# Altium schematic sheet LIN.SchDoc
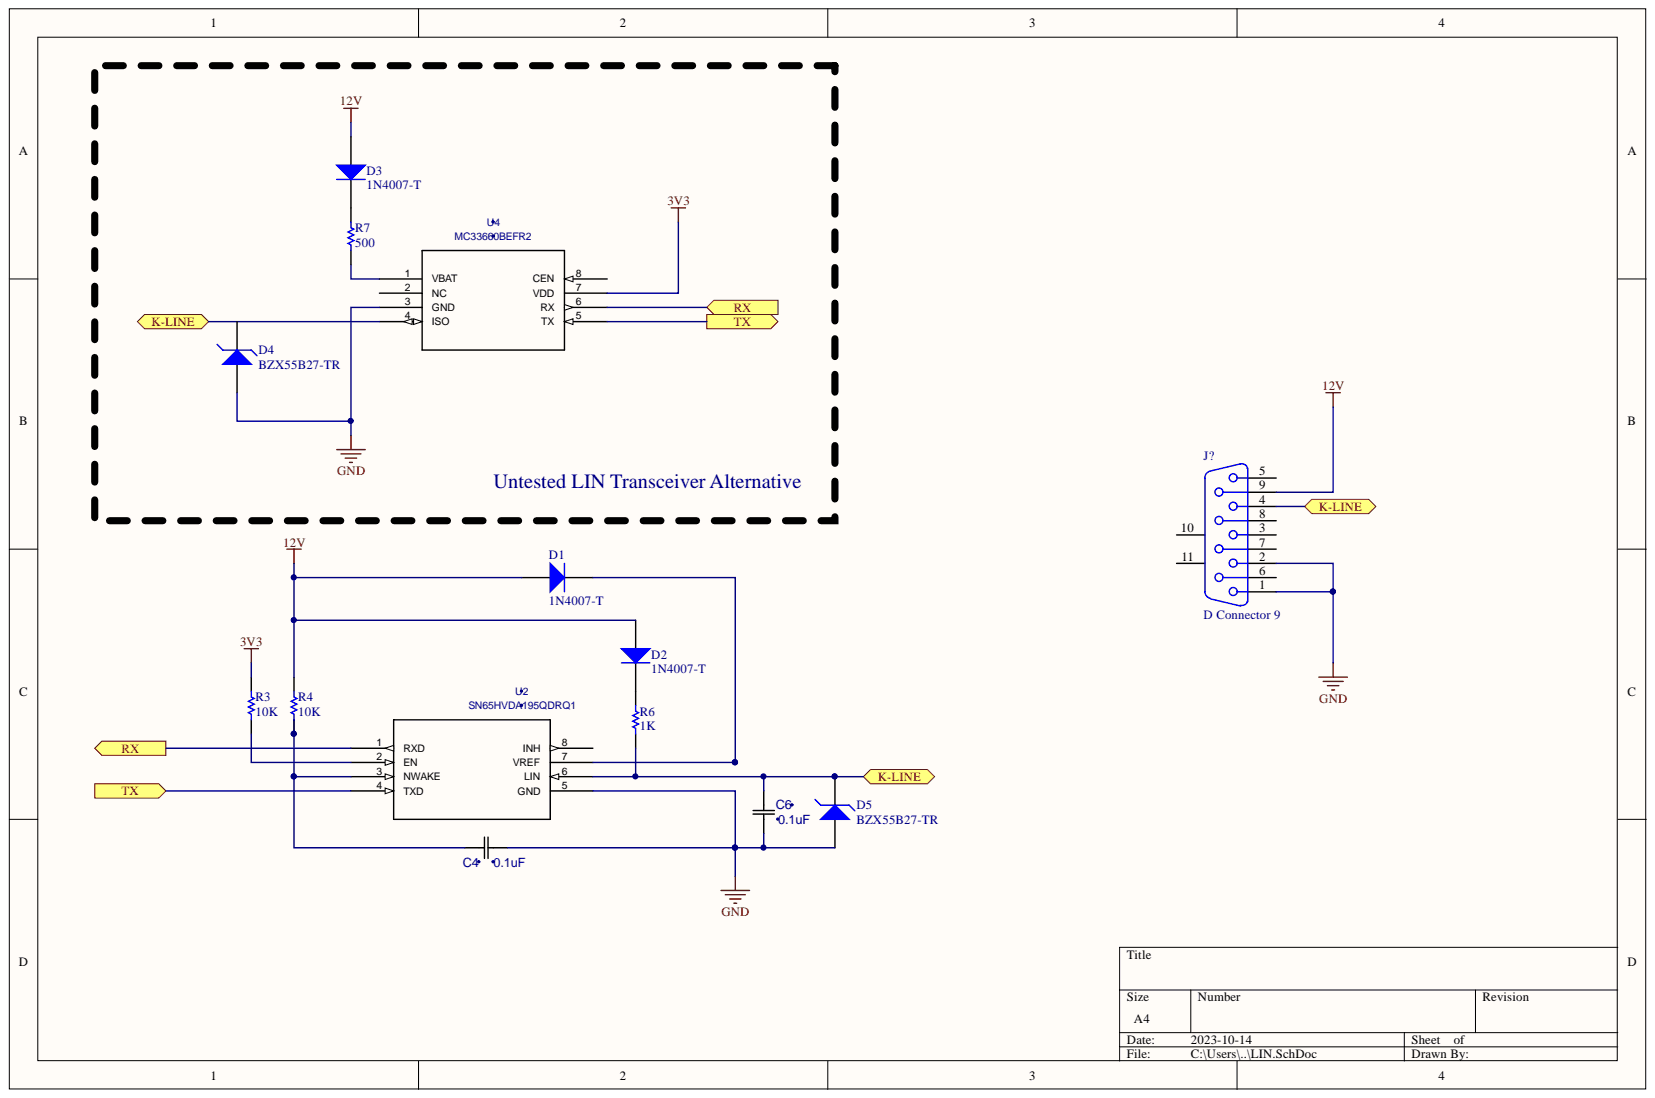
[diagram p0: LIN.SchDoc]

## Parts
C4  value 0.1uF  [CAP_TH_CER_5MM]  (hidden comment: CAP_TH_CER)
C6  value 0.1uF  [CAP_TH_CER_5MM]  (hidden comment: CAP_TH_CER)
D1  1N4007-T
D2  1N4007-T
D3  1N4007-T
D4  BZX55B27-TR
D5  BZX55B27-TR
J?  D Connector 9  [DSUB1.385-2H9]
R3  10K
R4  10K
R6  1K
R7  500
U2  SN65HVDA195QDRQ1  [8-Pin SOIC]
U4  MC33660BEFR2  [8-SOIC-3.90MM]

## Ports
K-LINE, RX, TX

## Nets
3V3: R3.2 U4.7(VDD)
12V: D1.1 D2.1 D3.1 J?.9 R4.2
GND: C4.1 C6.1 D4.2 D5.2 J?.1 J?.2 U2.5(GND) U4.3(GND)
K-LINE: C6.2 D5.1 J?.4 R6.1 U2.6(LIN) U4.4(ISO) ; port K-LINE
RX: U2.1(RXD) U4.6(RX) ; port RX
TX: U2.4(TXD) ; port TX
NetC4_2: C4.2 R4.1 U2.3(NWAKE)
NetD1_2: D1.2 U2.7(VREF)
NetD2_2: D2.2 R6.2
NetD3_2: D3.2 R7.2
NetR3_1: R3.1 U2.2(EN)
NetR7_1: R7.1 U4.1(VBAT)

Not connected: D4 1; J? 3 5 6 7 8 10 11; U2 8(INH); U4 2(NC) 5(TX) 8(CEN)

## Library data of the parts
C4 C6 = CAP_TH_CER: Mfr_Name: TDK; Component Kind: Standard; Library Name: JCLib.SchLib
D1-D3 = DIODE: Component Kind: Standard; Description: 1N4007-T; Library Name: JCLib.SchLib
D4 D5 = DIODE_ZENER: Zener Diode; Component Kind: Standard; MPN: ?; Library Name: JCLib.SchLib
J? = D Connector 9: Receptacle Assembly, 9 Position, Right Angle; PackageDocument: O; Positions: 9; Component Kind: Standard; DatasheetDocument: 1-Sep-1999; Gender: Receptacle; LatestRevisionDate: 17-Jul-2002; LatestRevisionNote: Re-released for DXP Platform.; Library Name: Miscellaneous Connectors.IntLib; Orientation: Right Angle; PackageReference: 788750; Published: 15-Jun-2001; Publisher: Altium Limited
R3 R4 R6 R7 = RES: Resistor; Value: ? Ohms; Component Kind: Standard; MPN: ?; Power: ?; SuppliersPartNumber: ?; Library Name: JCLib.SchLib
U2 = IC_LIN_SN65HVDA195QDRQ1: Mfr_Name: Texas Instruments; Component Kind: Standard; Manufacturer_Part_Number: SN65HVDA195QDRQ1; Value: SN65HVDA195QDRQ1; Library Name: JCLib.SchLib
U4 = IC_LIN_MC33660BEFR2: Mfr_Name: NXP; Component Kind: Standard; Manufacturer_Part_Number: MC33660BEFR2; Value: MC33660BEFR2; Library Name: JCLib.SchLib
